# Altium schematic sheet Hexabit.SchDoc
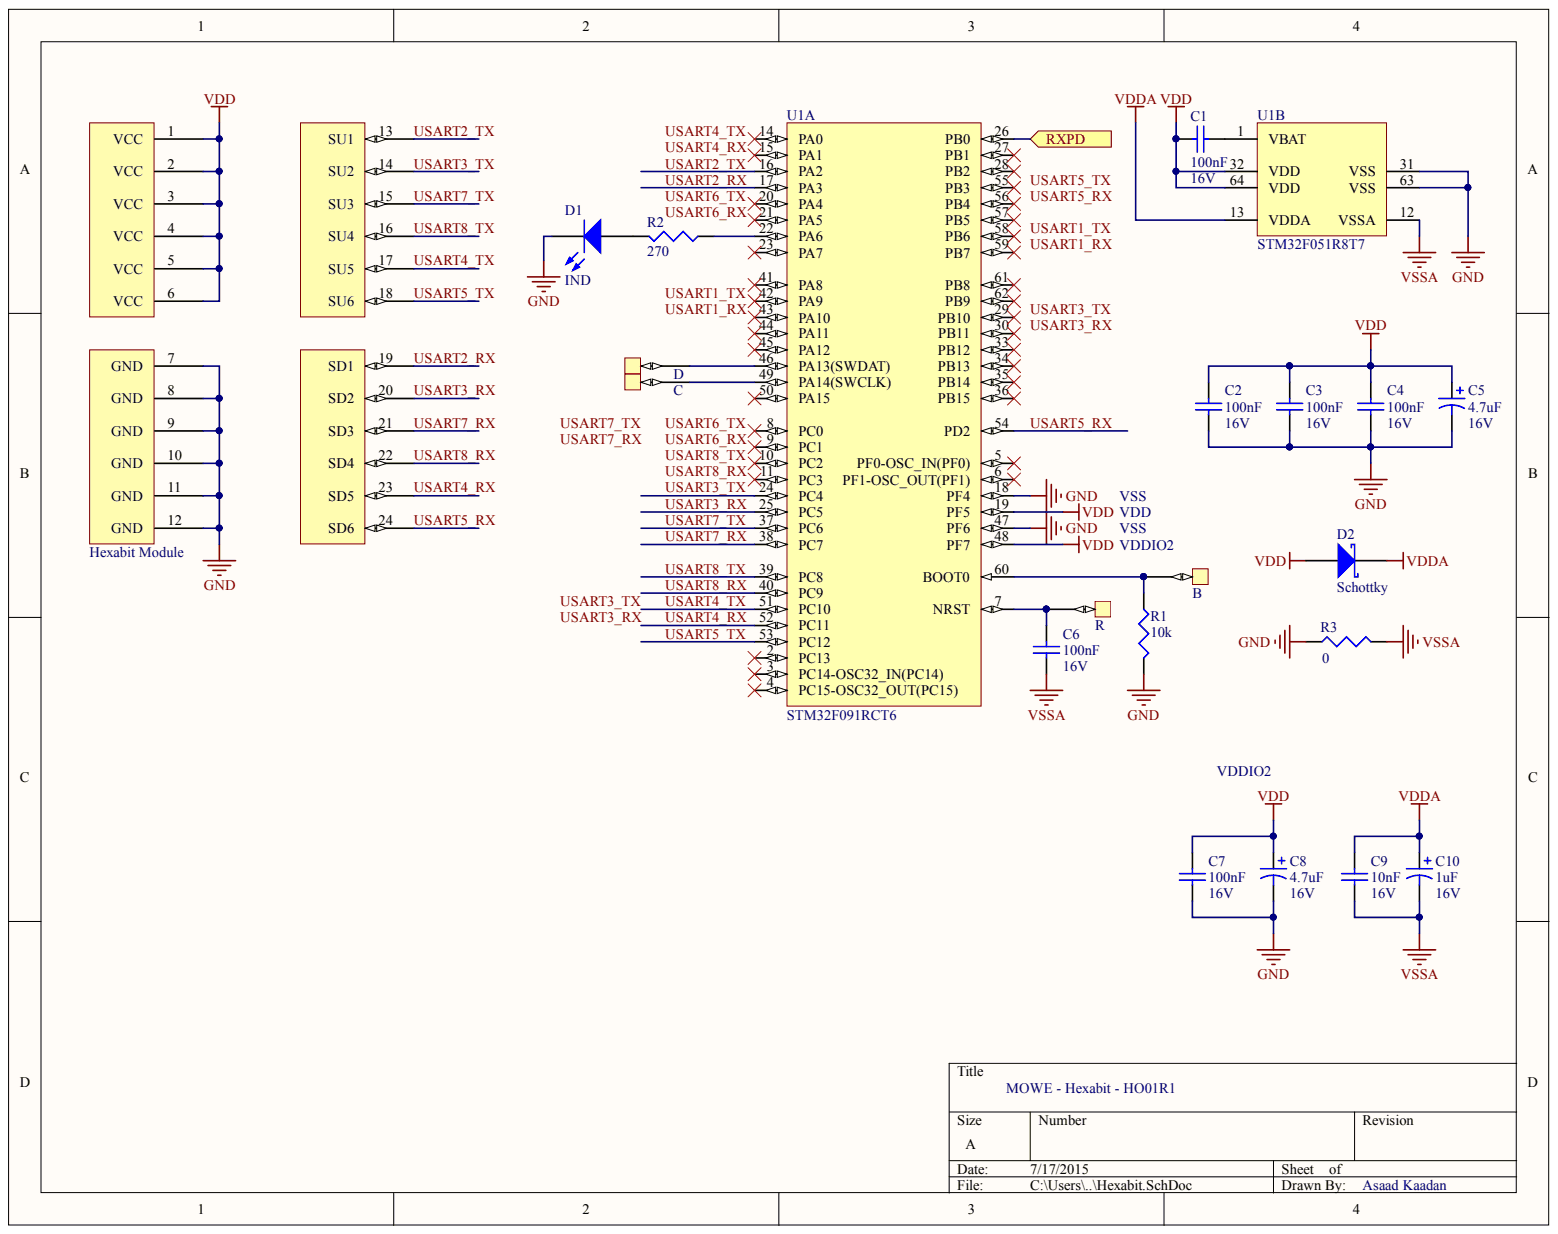
[diagram p0: Hexabit.SchDoc]

## Parts
B  SMD_Pin  [SMD_Pin]  (value not drawn)
C  SMD_Pin  [SMD_Pin]  (value not drawn)
C1  value 100nF  [1608[0603]]  (hidden comment: Cap Semi)
C2  value 100nF  Voltage: 16V  [1608[0603]]  (hidden comment: Cap Semi)
C3  value 100nF  Voltage: 16V  [1608[0603]]  (hidden comment: Cap Semi)
C4  value 100nF  Voltage: 16V  [1608[0603]]  (hidden comment: Cap Semi)
C5  value 4.7uF  Voltage: 16V  [C0805]  (hidden comment: Cap Pol3)
C6  value 100nF  Voltage: 16V  [1608[0603]]  (hidden comment: Cap Semi)
C7  value 100nF  Voltage: 16V  [1608[0603]]  (hidden comment: Cap Semi)
C8  value 4.7uF  Voltage: 16V  [C0805]  (hidden comment: Cap Pol3)
C9  value 10nF  Voltage: 16V  [1608[0603]]  (hidden comment: Cap Semi)
C10  value 1uF  Voltage: 16V  [C0805]  (hidden comment: Cap Pol3)
D  SMD_Pin  [SMD_Pin]  (value not drawn)
D1  IND  [1608[0603]]
D2  Schottky  [ONSC-SOD123-2-498_A_V]
P1  Hexabit Module  [hexabit-30mm]
R  SMD_Pin  [SMD_Pin]  (value not drawn)
R1  value 10k  [J1-0603]  (hidden comment: Res3)
R2  value 270  [J1-0603]  (hidden comment: Res3)
R3  value 0  [J1-0603]  (hidden comment: GND Jumper)
U1  STM32F091RCT6  [STM-LQFP64_N]  (parts A,B)

## Ports
RXPD

## Nets
GND: C2.2 C3.2 C4.2 C5.2 C7.2 C8.2 D1.2 P1.7 P1.8 P1.9 P1.10 P1.11 P1.12 R1.2 R3.1 U1.18(PF4) U1.31(VSS) U1.47(PF6) U1.63(VSS)
VDD: C1.1 C2.1 C3.1 C4.1 C5.1 C7.1 C8.1 D2.2 P1.1 P1.2 P1.3 P1.4 P1.5 P1.6 U1.19(PF5) U1.32(VDD) U1.48(PF7) U1.64(VDD)
VDDA: C9.1 C10.1 D2.1 U1.13(VDDA)
VSSA: C6.2 C9.2 C10.2 R3.2 U1.12(VSSA)
RXPD: U1.26(PB0) ; port RXPD
USART2_RX: P1.19(SD1) U1.17(PA3)
USART2_TX: P1.13(SU1) U1.16(PA2)
USART3_RX: P1.20(SD2) U1.25(PC5)
USART3_TX: P1.14(SU2) U1.24(PC4)
USART4_RX: P1.23(SD5) U1.52(PC11)
USART4_TX: P1.17(SU5) U1.51(PC10)
USART5_RX: P1.24(SD6) U1.54(PD2)
USART5_TX: P1.18(SU6) U1.53(PC12)
USART7_RX: P1.21(SD3) U1.38(PC7)
USART7_TX: P1.15(SU3) U1.37(PC6)
USART8_RX: P1.22(SD4) U1.40(PC9)
USART8_TX: P1.16(SU4) U1.39(PC8)
NetB_0: B.0 R1.1 U1.60(BOOT0)
NetC1_2: C1.2 U1.1(VBAT)
NetC6_1: C6.1 R.0 U1.7(NRST)
NetC_0: C.0 U1.49(PA14(SWCLK))
NetD1_1: D1.1 R2.2
NetD_0: D.0 U1.46(PA13(SWDAT))
NetR2_1: R2.1 U1.22(PA6)
USART1_RX:
USART1_TX:
USART6_RX:
USART6_TX:

Not connected: U1 2(PC13) 3(PC14-OSC32_IN(PC14)) 4(PC15-OSC32_OUT(PC15)) 5(PF0-OSC_IN(PF0)) 6(PF1-OSC_OUT(PF1)) 8(PC0) 9(PC1) 10(PC2) 11(PC3) 14(PA0) 15(PA1) 20(PA4) 21(PA5) 23(PA7) 27(PB1) 28(PB2) 29(PB10) 30(PB11) 33(PB12) 34(PB13) 35(PB14) 36(PB15) 41(PA8) 42(PA9) 43(PA10) 44(PA11) 45(PA12) 50(PA15) 55(PB3) 56(PB4) 57(PB5) 58(PB6) 59(PB7) 61(PB8) 62(PB9)

## Library data of the parts
C1 = Cap Semi: Capacitor (Semiconductor SIM Model); Voltage: 16V; Component Kind: Standard; LatestRevisionDate: 29-May-2009; LatestRevisionNote: IPC-7351 Footprint Added.; Library Name: Miscellaneous Devices.IntLib; PackageDescription: Chip Capacitor; PackageReference: C0805; Published: 8-Jun-2000; Signal Integrity: Cap Semi; Simulation: CAP; Supplier 1: Digi-Key; Supplier Part Number 1: 445-1326-1-ND; Publisher: Altium Limited
C2-C4 C6 C7 C9 = Cap Semi: Capacitor (Semiconductor SIM Model); Component Kind: Standard; LatestRevisionDate: 29-May-2009; LatestRevisionNote: IPC-7351 Footprint Added.; Library Name: Miscellaneous Devices.IntLib; PackageDescription: Chip Capacitor; PackageReference: C0805; Published: 8-Jun-2000; Signal Integrity: Cap Semi; Simulation: CAP; Supplier 1: Digi-Key; Publisher: Altium Limited
  C2-C4 C6 C7: Supplier Part Number 1: 445-1326-1-ND
  C9: Supplier Part Number 1: 445-6855-1-ND
C5 C8 C10 = Cap Pol3: Polarized Capacitor (Surface Mount); Component Kind: Standard; LatestRevisionDate: 29-May-2009; LatestRevisionNote: IPC-7351 Footprint Added.; Library Name: Miscellaneous Devices.IntLib; PackageDescription: Chip Capacitor; Published: 8-Jun-2000; Signal Integrity: Cap Pol; Simulation: CAP; Supplier 1: Digi-Key; Publisher: Altium Limited
  C5 C8: PackageReference: C1206; Supplier Part Number 1: 478-8475-1-ND
  C10: PackageReference: C0805; Supplier Part Number 1: 511-1467-1-ND
D1 = LED2: Typical RED, GREEN, YELLOW, AMBER GaAs LED; Component Kind: Standard; LatestRevisionDate: 17-Jul-2002; LatestRevisionNote: Re-released for DXP Platform.; Library Name: Miscellaneous Devices.IntLib; PackageDescription: SM LED; 2 Flat Leads; PackageReference: 3.2X1.6X1.1; PackageVersion: Feb-2002; Published: 8-Jun-2000; Simulation: LED2; Supplier 1: Digi-Key; Supplier Part Number 1: LNJ237W82RACT-ND; Publisher: Altium Limited
D2 = D Schottky: Schottky Diode; Code_JEDEC: DO-214-AA; Component Kind: Standard; LatestRevisionDate: 17-Jul-2002; LatestRevisionNote: Re-released for DXP Platform.; Library Name: Miscellaneous Devices.IntLib; PackageDescription: DO-214-AA/SMB; 2 C-Bend Leads; Body 5.3 x 3.6 mm, inc. leads (LxW); PackageReference: SMB; Published: 8-Jun-2000; Signal Integrity: D Schottky; Simulation: SKYDIODE; Supplier 1: Digi-Key; Supplier Part Number 1: 568-4105-1-ND; Publisher: Altium Limited
P1 = hexabit_conn: Component Kind: Standard; Library Name: hexabit.IntLib
R1 R2 = Res3: Resistor; Tolerance: 5%; Component Kind: Standard; LatestRevisionDate: 29-May-2009; LatestRevisionNote: IPC-7351 Footprint Added.; Library Name: Miscellaneous Devices.IntLib; PackageDescription: Chip Resistor; Published: 8-Jun-2000; Signal Integrity: Res; Simulation: RESISTOR; Supplier 1: Digi-Key; Publisher: Altium Limited
  R1: PackageReference: 0805; Supplier Part Number 1: P10KGCT-ND
  R2: PackageReference: J1-0603; Supplier Part Number 1: P270BZCT-ND
R3 = Res3: Component Kind: Net Tie; Description: GND Jumper; LatestRevisionDate: 29-May-2009; LatestRevisionNote: IPC-7351 Footprint Added.; Library Name: Miscellaneous Devices.IntLib; PackageDescription: Chip Resistor; PackageReference: J1-0603; Published: 8-Jun-2000; Signal Integrity: Res; Simulation: RESISTOR; Supplier 1: Digi-Key; Supplier Part Number 1: P0.0GCT-ND; Publisher: Altium Limited
U1 = STM32F051R8T7: ARM Cortex-M0 32-bit MCU, 64 KB Flash, 8 KB Internal RAM, 55 I/Os, 64-pin LQFP, -40 to 105 degC, Tray; FLASH Size (Prog) (kB): 64; I/Os (High Current): 55; Internal RAM Size (kB): 8; Operating Frequency(F) (Processor speed) (MHz): 48; Supply Voltage(Vcc) min (V): 2; Component Kind: Standard; ComponentLink1Description: Manufacturer URL; ComponentLink1URL: http://www.st.com/web/en/home.html; ComponentLink2Description: Datasheet; ComponentLink2URL: http://www.st.com/st-web-ui/static/active/en/resource/technical/document/datasheet/DM00039193.pdf; ComponentLink3Description: Package Specification; ComponentLink3URL: http://www.st.com/st-web-ui/static/active/en/resource/technical/document/datasheet/DM00037051.pdf; Core: ARM Cortex-M0; DatasheetVersion: Rev. 3; Library Name: STMicroelectronics STM32 F0.IntLib; Mounting Technology: Surface Mount; PackageDescription: 64-Pin Low Profile Quad Flat Package, 10 x 10 mm Body, 0.5 mm Pitch; PackageReference: LQFP64; PackageVersion: Rev. 4, 06/2013; Packing: Tray; PartNumber: STM32F051R8T7; Supplier 1: Newark; Supplier 2: Digi-Key; Supplier Part Number 1: 48W6868; Supplier Part Number 2: 497-15167-ND
B C D R = SMD_Pin: Component Kind: Standard; Library Name: hexabit.IntLib
